# Altium schematic sheet Amplifiers.SchDoc(Amplifiers)
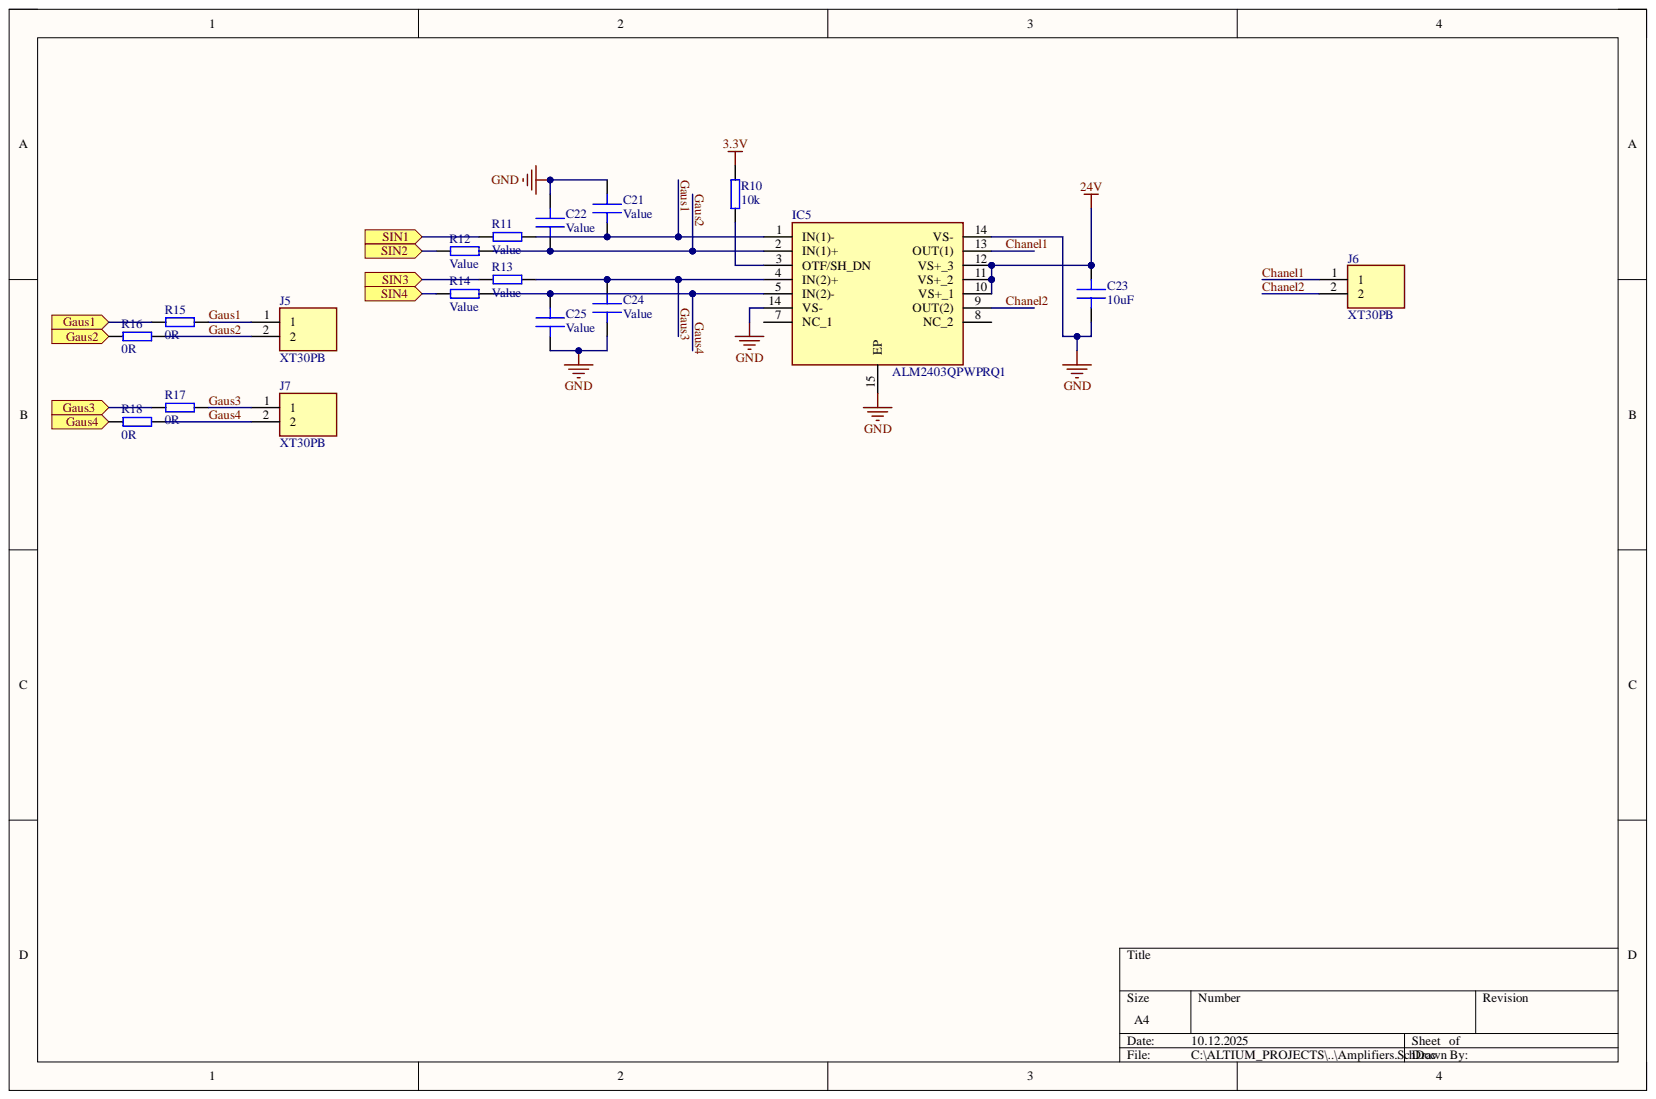
[diagram p0: Amplifiers.SchDoc]

## Parts
C21  Value  [C 0402]
C22  Value  [C 0402]
C23  10uF  [C 0805]
C24  Value  [C 0402]
C25  Value  [C 0402]
IC5  ALM2403QPWPRQ1  [SOP65P640X120-15N]
J5  XT30PB  [XT30PB]
J6  XT30PB  [XT30PB]
J7  XT30PB  [XT30PB]
R10  10k  [R 0402]
R11  Value  [R 0402]
R12  Value  [R 0402]
R13  Value  [R 0402]
R14  Value  [R 0402]
R15  0R  [R 0402]
R16  0R  [R 0402]
R17  0R  [R 0402]
R18  0R  [R 0402]

## Ports
Gaus1, Gaus2, Gaus3, Gaus4, SIN1, SIN2, SIN3, SIN4

## Nets
3.3V: R10.2
24V: C23.1 IC5.10(VS+_1) IC5.11(VS+_2) IC5.12(VS+_3)
GND: C21.1 C22.1 C23.2 C24.2 C25.2 IC5.14(VS-) IC5.15(EP)
Chanel1: IC5.13(OUT(1)) J6.1
Chanel2: IC5.9(OUT(2)) J6.2
Gaus1: C21.2 IC5.1(IN(1)-) J5.1 R11.2 R15.2
Gaus2: C22.2 IC5.2(IN(1)+) J5.2 R12.2 R16.2
Gaus3: C24.1 IC5.4(IN(2)+) J7.1 R13.2 R17.2
Gaus4: C25.1 IC5.5(IN(2)-) J7.2 R14.2 R18.2
SIN1: R11.1 ; port SIN1
SIN2: R12.1 ; port SIN2
SIN3: R13.1 ; port SIN3
SIN4: R14.1 ; port SIN4
NetIC5_3: IC5.3(OTF/SH_DN) R10.1
NetR15_1: R15.1 ; port Gaus1
NetR16_1: R16.1 ; port Gaus2
NetR17_1: R17.1 ; port Gaus3
NetR18_1: R18.1 ; port Gaus4

Not connected: IC5 7(NC_1) 8(NC_2)

## Library data of the parts
C21-C25 = Capacitor: MLCC Capacitor; Component Kind: Standard; Library Name: Components.IntLib
IC5 = ALM2403QPWPRQ1: Integrated Circuit; Manufacturer_Name: Texas Instruments; Arrow Part Number: ALM2403QPWPRQ1; Arrow Price/Stock: https://www.arrow.com/en/products/alm2403qpwprq1/texas-instruments?region=nac; Component Kind: Standard; Datasheet Link: https://www.ti.com/lit/ds/symlink/alm2403-q1.pdf?ts=1609171403376&ref_url=https%253A%252F%252Fwww.mouser.de%252F; Height: 1.2mm; Manufacturer_Part_Number: ALM2403QPWPRQ1; Mouser Part Number: 595-ALM2403QPWPRQ1; Mouser Price/Stock: https://www.mouser.co.uk/ProductDetail/Texas-Instruments/ALM2403QPWPRQ1?qs=KUoIvG%2F9IlbGB6vKF5Gy0A%3D%3D
J5-J7 = XT30PB: Connector; Manufacturer_Name: Amass; Component Kind: Standard; Datasheet Link: https://www.tme.eu/Document/4acc913878197f8c2e30d4b8cdc47230/XT30UPB%20SPEC.pdf; Height: 10.7mm; Library Name: SamacSys.SchLib; Manufacturer_Part_Number: XT30PB
R10-R18 = Resistor: General pourpouse resistor; Component Kind: Standard; Library Name: Components.IntLib
